# Altium schematic sheet MicroSD.SchDoc
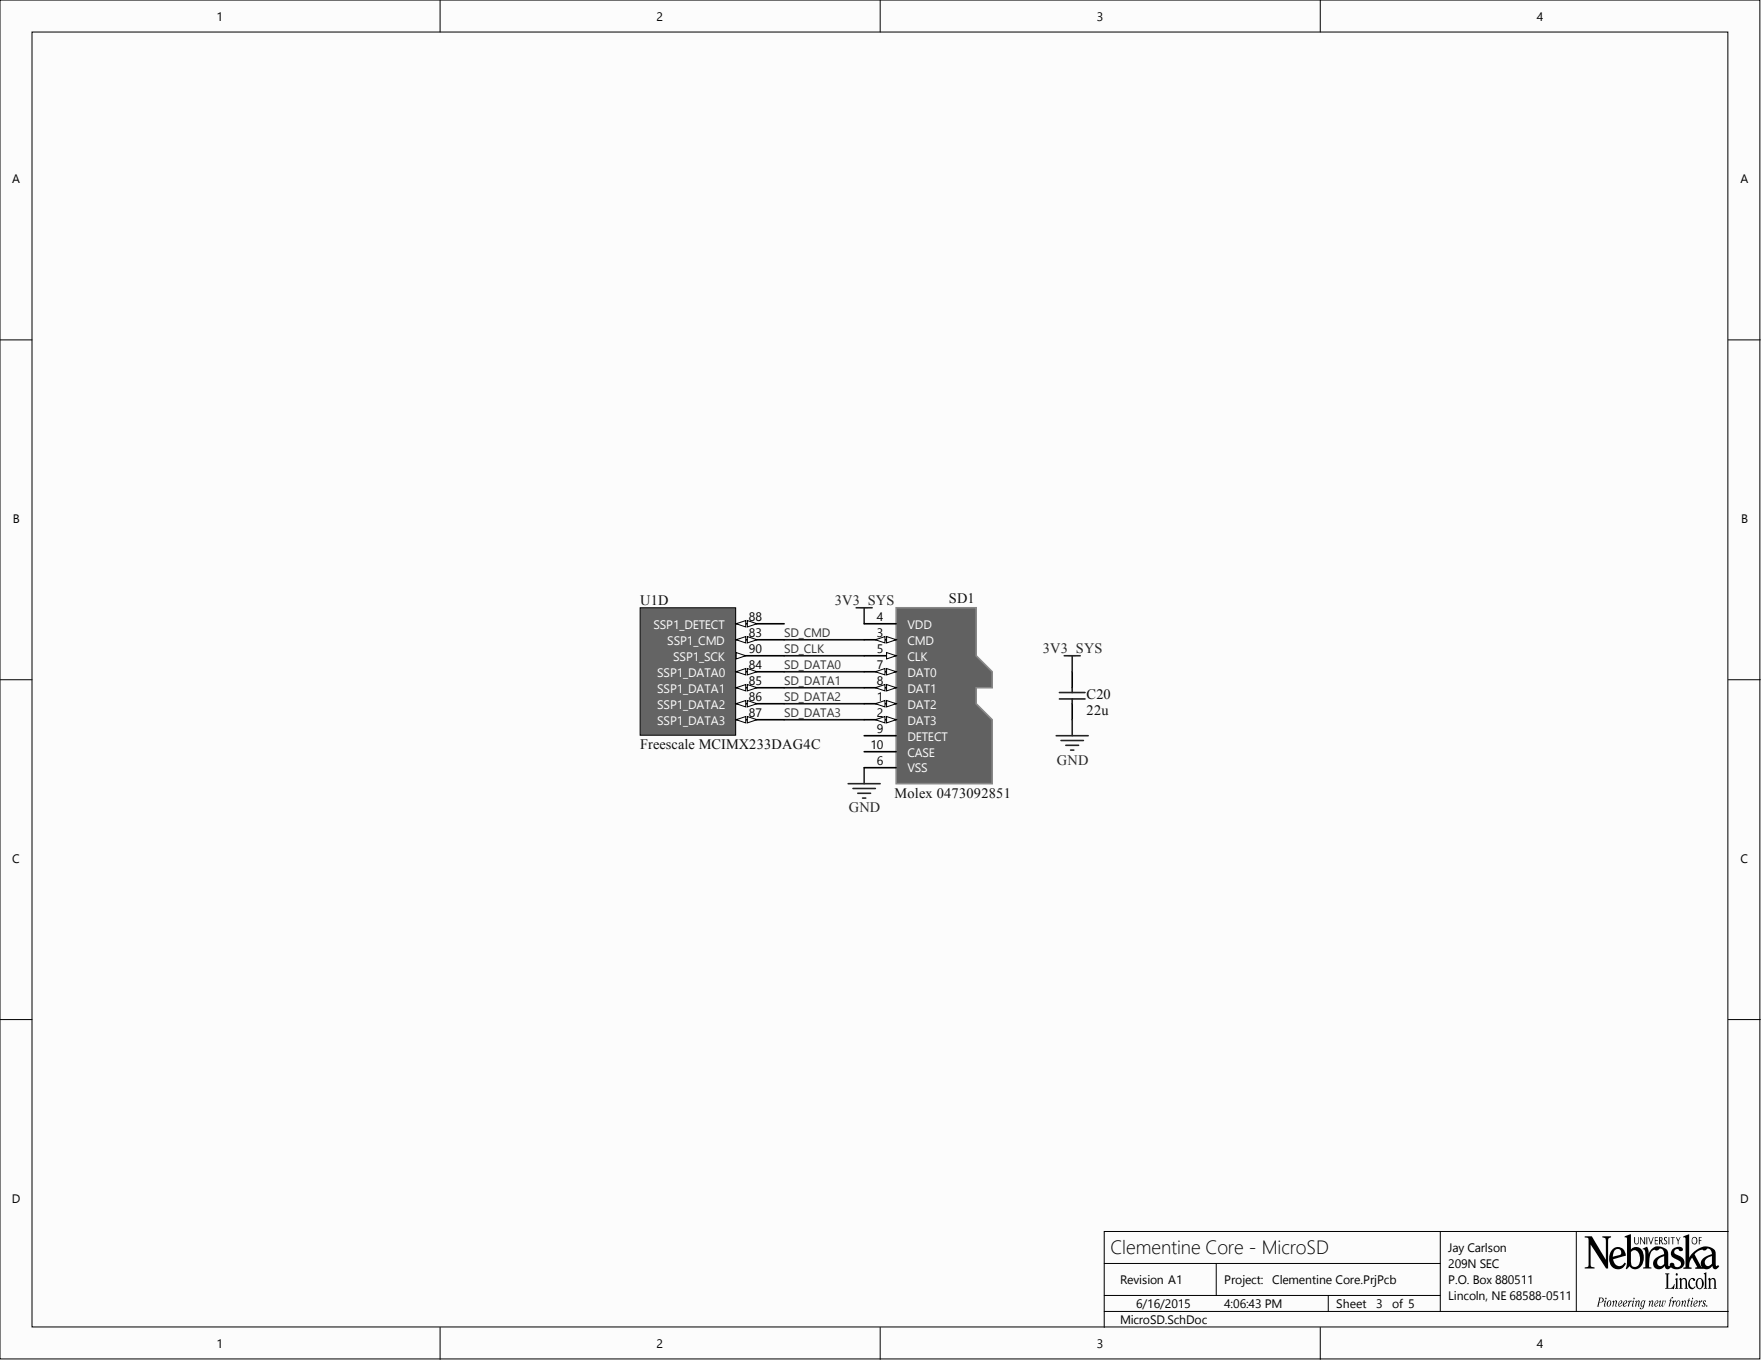
[diagram p0: MicroSD.SchDoc]

## Parts
C20  22u  [CAPC1608X90X35ML10T15]
SD1  Molex 0473092851  Manufacturer Part Number: 0473092851  [PCB-616248-1]
U1  Freescale MCIMX233DAG4C  Manufacturer Part Number: MCIMX233DAG4C  [PCB-1234233-1]  (part D)

## Nets
3V3_SYS: C20.2 SD1.4(VDD)
GND: C20.1 SD1.6(VSS)
SD_CLK: SD1.5(CLK) U1.90(SSP1_SCK)
SD_CMD: SD1.3(CMD) U1.83(SSP1_CMD)
SD_DATA0: SD1.7(DAT0) U1.84(SSP1_DATA0)
SD_DATA1: SD1.8(DAT1) U1.85(SSP1_DATA1)
SD_DATA2: SD1.1(DAT2) U1.86(SSP1_DATA2)
SD_DATA3: SD1.2(DAT3) U1.87(SSP1_DATA3)

Not connected: SD1 9(DETECT) 10(CASE); U1 88(SSP1_DETECT)

## Library data of the parts
C20 = CMP-133494-2: Cap Ceramic 1uF 25V X5R 20% SMD 0603 85C Paper T/R; Manufacturer Part Number: GRM188R61E105MA12D; CiivaID: 133494; Rated Voltage: 25VDC; Category: Passives › Capacitor › Ceramic capacitor; Ciiva URL: https://ciiva.com/part/grm188r61e105ma12d-133494; Component Kind: Standard; Diameter: N/R; Height: 0.8mm; Length: 1.6mm; Library Name: CommunityVault; Maximum operating temperature: 85 C; Minimum operating temperature: -55 C; Size: 1.6 X 0.8 X 0.8mm; Temperature coefficient: X5R; Tolerance: 20%; Width: 0.8mm
SD1 = CMP-616248-3: Conn Micro SD Card HDR 8 POS 1.1mm Solder RA SMD Embossed T/R 0.5A/Contact; 3D Model: 47309-2851.stp; Card Type: Secure Digital - microSD™ TransFlash; CiivaID: 616248; Connector Type: Connector; Dynamic Catalog: microSD™ Series; Insertion, Removal Method: Push In, Pull Out; Number of Positions: 9 (8 + 1); Other Names: WM6701DKR; Category: Connectors, Interconnects; Ciiva URL: https://ciiva.com/part/0473092851-616248; Component Kind: Standard; Contact Finish: Gold; Contact Finish Thickness: 30µin (0.76µm); Family: Memory Connectors - PC Cards; Features: Switch; Height Above Board: 0.112" (2.85mm); Library Name: CommunityVault; Mounting Feature: Normal, Standard - Top; Mounting Type: Surface Mount, Right Angle; Packaging: Digi-Reel®; Series: 47309; Standard Package: 1
U1 = CMP-1234233-6: MPU 32BIT I.MX233 128LQFP; Processing Unit: Microprocessor; Rad Hardened: No; Screening Level: Commercial; CiivaID: 1234233; Ciiva URL: https://ciiva.com/part/mcimx233dag4c-1234233; Component Kind: Standard; Library Name: CommunityVault; Mounting: Surface Mount; Package Type: LQFP; Packaging: Tray; Pin Count: 128; Technology: 0.09um
